# Altium schematic sheet 5V_Project_Microcontrollers_Schematic.SchDoc
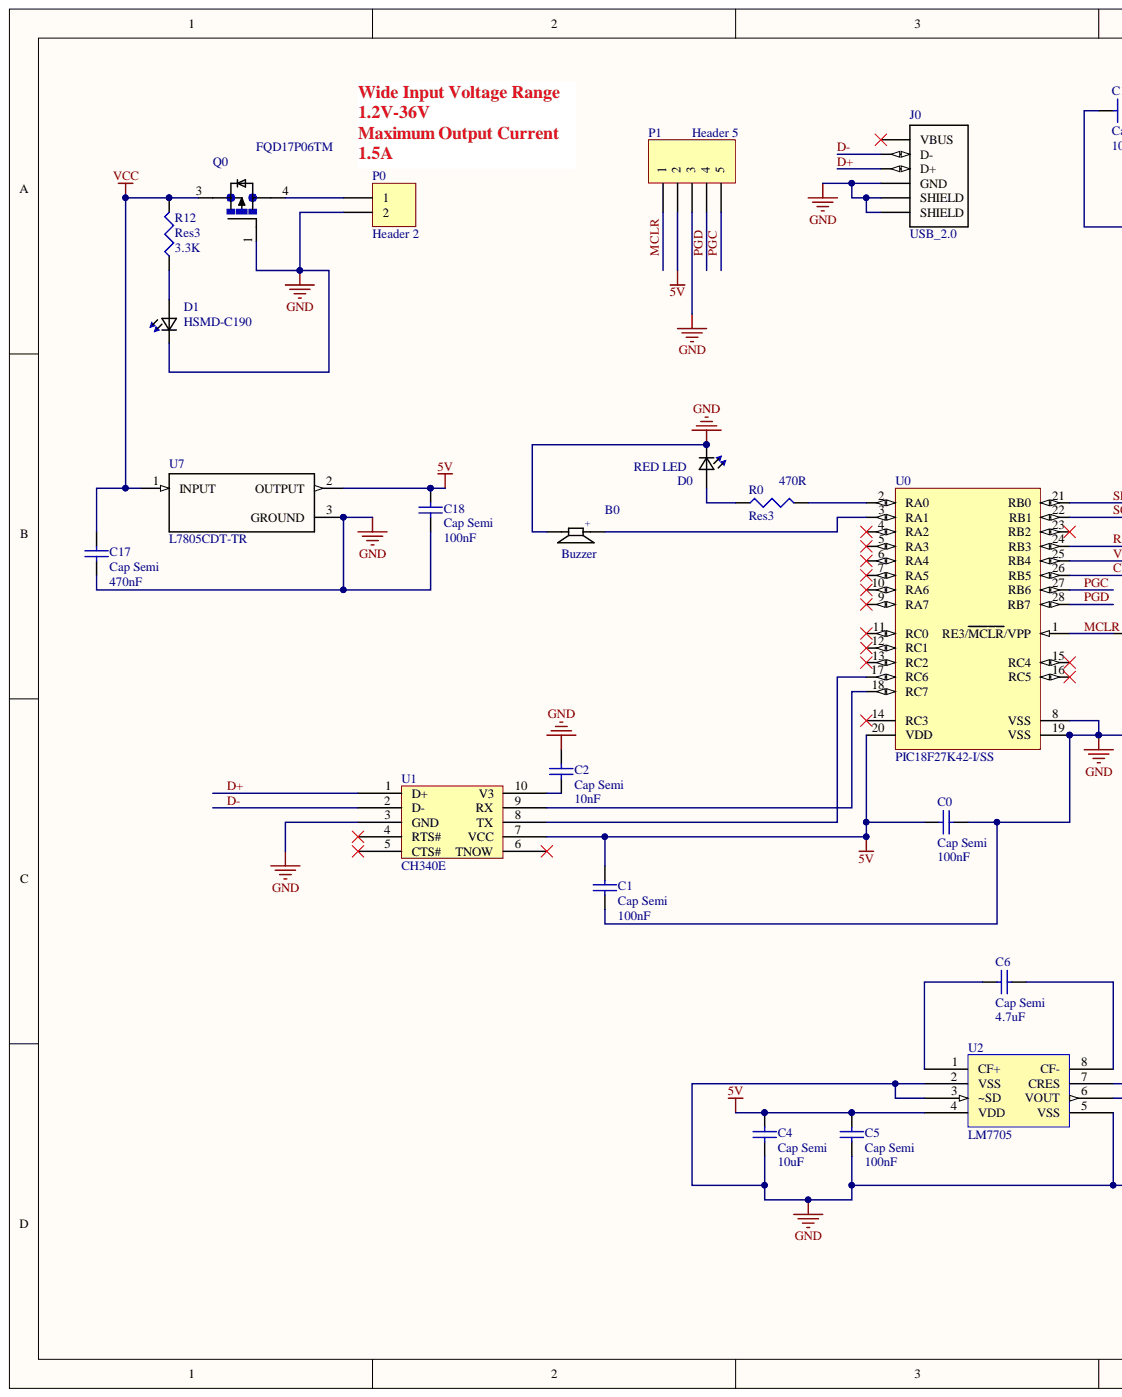
[diagram p0: 5V_Project_Microcontrollers_Schematic.SchDoc, left]
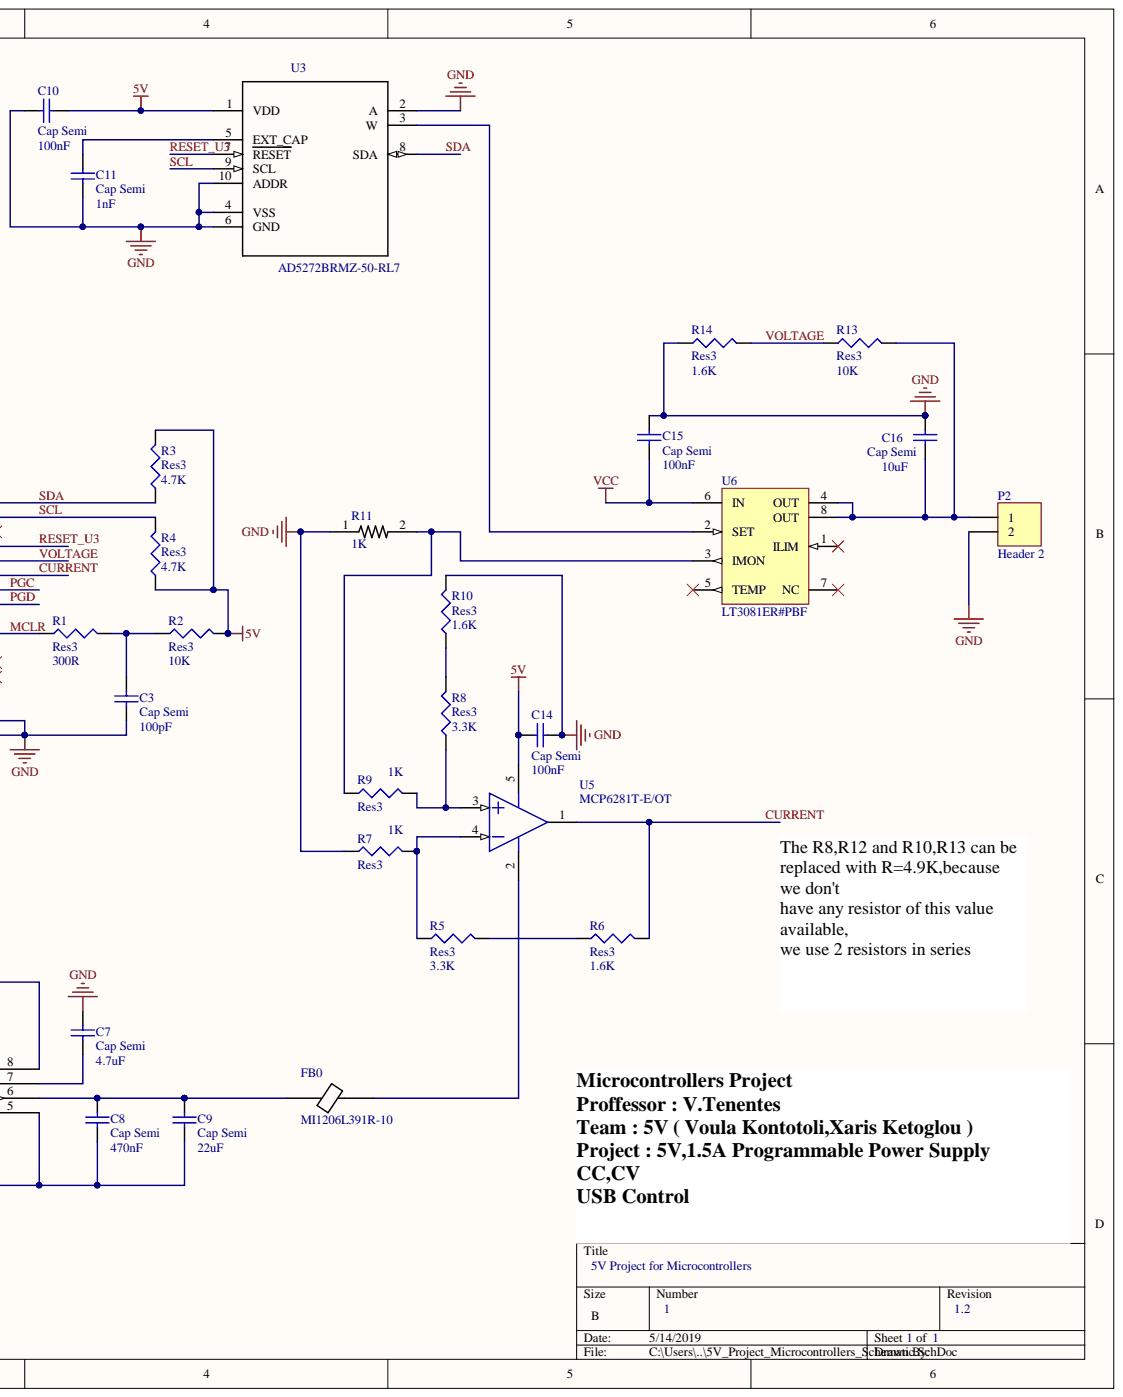
[diagram p1: 5V_Project_Microcontrollers_Schematic.SchDoc, right]

## Parts
B0  Buzzer  [CUI_CEM-1212S_CEM-1212S(Primary)]
C0  Cap Semi  value 100nF  [1608[0603]]
C1  Cap Semi  value 100nF  [1608[0603]]
C2  Cap Semi  value 10nF  [1608[0603]]
C3  Cap Semi  value 100pF  [1608[0603]]
C4  Cap Semi  value 10uF  [1608[0603]]
C5  Cap Semi  value 100nF  [1608[0603]]
C6  Cap Semi  value 4.7uF  [1608[0603]]
C7  Cap Semi  value 4.7uF  [1608[0603]]
C8  Cap Semi  value 470nF  [1608[0603]]
C9  Cap Semi  value 22uF  [1608[0603]]
C10  Cap Semi  value 100nF  [1608[0603]]
C11  Cap Semi  value 1nF  [1608[0603]]
C14  Cap Semi  value 100nF  [1608[0603]]
C15  Cap Semi  value 100nF  [1608[0603]]
C16  Cap Semi  value 10uF  [1608[0603]]
C17  Cap Semi  value 470nF  [1608[0603]]
C18  Cap Semi  value 100nF  [1608[0603]]
D0  RED LED  [0603]
D1  HSMD-C190  [0603]
FB0  MI1206L391R-10  [*BEADC3216X130N]
J0  USB_2.0  [*GCT_USB1046-XX-XXXX-X-B-X_REVB_USB1046-XX-XXXX-X-B-X_REVB(Primary)]
P0  Header 2  [MSTBA 2,5/ 2-G-5,08]
P1  Header 5  [HDR1X5]
P2  Header 2  [HDR1X2]
Q0  FQD17P06TM  [*DPAK229P990X239-4N]
R0  Res3  value 470R  [J1-0603]
R1  Res3  value 300R  [J1-0603]
R2  Res3  value 10K  [J1-0603]
R3  Res3  value 4.7K  [J1-0603]
R4  Res3  value 4.7K  [J1-0603]
R5  Res3  value 3.3K  [J1-0603]
R6  Res3  value 1.6K  [J1-0603]
R7  Res3  value 1K  [J1-0603]
R8  Res3  value 3.3K  [J1-0603]
R9  Res3  value 1K  [J1-0603]
R10  Res3  value 1.6K  [J1-0603]
R11  1K  [*RESC3216X90]
R12  Res3  value 3.3K  [J1-0603]
R13  Res3  value 10K  [J1-0603]
R14  Res3  value 1.6K  [J1-0603]
U0  PIC18F27K42-I/SS  [MCHP-SSOP-SS28_N]
U1  CH340E  [MSOP10]
U2  LM7705  [MUA08A]
U3  AD5272BRMZ-50-RL7  [SOP50P490X110-10N]
U5  MCP6281T-E/OT  [SOT-23-5_MC]
U6  LT3081ER#PBF  [LT-R-7_N]
U7  L7805CDT-TR  [*VREG_L7805CDT-TR]

## Nets
5V: C0.1 C1.1 C4.2 C5.2 C10.2 C14.2 C18.2 P1.2 R2.2 R3.2 R4.1 U0.20(VDD) U1.7(VCC) U2.4(VDD) U3.1(VDD) U5.5 U7.2
GND: B0.M C0.2 C1.2 C2.2 C3.1 C4.1 C5.1 C7.2 C8.1 C9.1 C10.1 C11.1 C14.1 C15.2 C16.2 C17.1 C18.1 D0.1 D1.1 J0.4(GND) J0.P1(SHIELD) J0.P2(SHIELD) P0.2 P1.3 P2.2 Q0.1 R7.1 R10.2 R11.1 R14.1 U0.8(VSS) U0.19(VSS) U1.3(GND) U2.2(VSS) U2.3(~SD) U2.5(VSS) U3.2(A) U3.4(VSS) U3.6(GND) U3.10(ADDR) U7.3
VCC: C15.1 C17.2 Q0.3 R12.2 U6.6(IN) U7.1
CURRENT: R6.2 U0.26(RB5) U5.1
D+: J0.3(D+) U1.1(D+)
D-: J0.2(D-) U1.2(D-)
MCLR: P1.1 R1.1 U0.1(RE3/MCLR/VPP)
PGC: P1.5 U0.27(RB6)
PGD: P1.4 U0.28(RB7)
RESET_U3: U0.24(RB3) U3.7(RESET)
SCL: R4.2 U0.22(RB1) U3.9(SCL)
SDA: R3.1 U0.21(RB0) U3.8(SDA)
VOLTAGE: R13.1 R14.2 U0.25(RB4)
NetB0_P: B0.P U0.3(RA1)
NetC2_1: C2.1 U1.10(V3)
NetC3_2: C3.2 R1.2 R2.1
NetC6_1: C6.1 U2.1(CF+)
NetC6_2: C6.2 U2.8(CF-)
NetC7_1: C7.1 U2.7(CRES)
NetC8_2: C8.2 C9.2 FB0.1 U2.6(VOUT)
NetC11_2: C11.2 U3.5(EXT_CAP)
NetC16_1: C16.1 P2.1 R13.2 U6.4(OUT) U6.8(OUT)
NetD0_2: D0.2 R0.1
NetD1_2: D1.2 R12.1
NetFB0_2: FB0.2 U5.2
NetP0_1: P0.1 Q0.4
NetR0_2: R0.2 U0.2(RA0)
NetR5_1: R5.1 R7.2 U5.4
NetR5_2: R5.2 R6.1
NetR8_1: R8.1 R9.2 U5.3
NetR8_2: R8.2 R10.1
NetR9_1: R9.1 R11.2 U6.3(IMON)
NetU0_17: U0.17(RC6) U1.8(TX)
NetU0_18: U0.18(RC7) U1.9(RX)
NetU3_3: U3.3(W) U6.2(SET)

Not connected: J0 1(VBUS); U0 4(RA2) 5(RA3) 6(RA4) 7(RA5) 9(RA7) 10(RA6) 11(RC0) 12(RC1) 13(RC2) 14(RC3) 15(RC4) 16(RC5) 23(RB2); U1 4(RTS#) 5(CTS#) 6(TNOW); U6 1(ILIM) 5(TEMP) 7(NC)

## Library data of the parts
B0 = CEM-1212S: Component Kind: Standard; Library Name: buzzer.IntLib
C0-C9 C11 C15-C18 = Cap Semi: Capacitor (Semiconductor SIM Model); Component Kind: Standard; LatestRevisionDate: 29-May-2009; LatestRevisionNote: IPC-7351 Footprint Added.; Library Name: Miscellaneous Devices.IntLib; PackageDescription: Chip Capacitor; PackageReference: C1206; Published: 8-Jun-2000; Signal Integrity: Cap Semi; Simulation: CAP; Publisher: Altium Limited
C10 C14 = Cap Semi: Capacitor (Semiconductor SIM Model); Value: 100nF; Component Kind: Standard; LatestRevisionDate: 29-May-2009; LatestRevisionNote: IPC-7351 Footprint Added.; Library Name: Miscellaneous Devices.IntLib; PackageDescription: Chip Capacitor; PackageReference: C1206; Published: 8-Jun-2000; Signal Integrity: Cap Semi; Simulation: CAP; Publisher: Altium Limited
D0 D1 = HSMD-C190: LED Uni-Color Orange 605nm 2-Pin Chip LED T/R; MF: Broadcom Limited; Availability: Unavailable; Component Kind: Standard; Library Name: LED_SMD.IntLib; MP: HSMD-C190; Package: 0603 Broadcom; Price: None; SnapEDA_Link: https://www.snapeda.com/parts/HSMD-C190/Broadcom%20Limited/view-part/?ref=snap
FB0 = MI1206L391R-10: EMI Ferrite Chip Bead, 1206, 2000MA, 390 Ohms @100MHz, DCR Max 0.080Ohm; MF: Laird-Signal Integrity; Availability: Unavailable; Component Kind: Standard; Library Name: Ferrite_Bead.SchLib; MP: MI1206L391R-10; Package: 1206 Laird; Price: None; SnapEDA_Link: https://www.snapeda.com/parts/MI1206L391R-10/Laird-Signal%20Integrity%20Products/view-part/?ref=snap
J0 = USB1046-XX-XXXX-X-B-X_REVB: USB A Skt, Top Mount, SMT, R/A, GF, Black, With 1.9mm shell stake, T&R; MF: Global Connector Technology; MP: USB1046-GF-0190-L-B-A; Availability: Unavailable; Component Kind: Standard; Library Name: USB1046-XX-XXXX-X-B-X_REVB.IntLib; Package: None; Price: None; SnapEDA_Link: https://www.snapeda.com/parts/USB1046-GF-0190-L-B-A/Global%20Connector%20Technology/view-part/?ref=snap
P0 P2 = Header 2: Header, 2-Pin; Component Kind: Standard; LatestRevisionDate: 17-Jul-2002; LatestRevisionNote: Re-released for DXP Platform.; Library Name: Miscellaneous Connectors.IntLib; Signal Integrity: Connector; Publisher: Altium Limited
P1 = Header 5: Header, 5-Pin; Component Kind: Standard; LatestRevisionDate: 17-Jul-2002; LatestRevisionNote: Re-released for DXP Platform.; Library Name: Miscellaneous Connectors.IntLib; Signal Integrity: Connector; Publisher: Altium Limited
Q0 = FQD17P06TM: Transistor: P-MOSFET; unipolar; 60V; 7.6A; 44W; DPAK; QFETÂ®; MF: ON Semiconductor; Availability: Unavailable; Component Kind: Standard; Library Name: FQD17P06TM.SchLib; MP: FQD17P06TM; Package: TO-252-3 ON Semiconductor; Price: None; SnapEDA_Link: https://www.snapeda.com/parts/FQD17P06TM/ON%20Semiconductor/view-part/?ref=snap
R0-R10 R12-R14 = Res3: Resistor; Component Kind: Standard; LatestRevisionDate: 29-May-2009; LatestRevisionNote: IPC-7351 Footprint Added.; Library Name: Miscellaneous Devices.IntLib; PackageDescription: Chip Resistor; PackageReference: J1-0603; Published: 8-Jun-2000; Signal Integrity: Res; Simulation: RESISTOR; Publisher: Altium Limited
R11 = WSLP12061L000FEA: SMD Current Sense Resistors, AEC-Q200 WSLP Series, 0.001 ohm, 1 W, 1%, 1206 [3216 Metric]; MF: Vishay Dale; Availability: Unavailable; Component Kind: Standard; Library Name: 1206_FOOTPRINT.SchLib; MP: WSLP12061L000FEA; Package: 1206 Vishay; Price: None; SnapEDA_Link: https://www.snapeda.com/parts/WSLP12061L000FEA/Vishay%20Dale/view-part/?ref=snap
U0 = PIC18F25K50-I/SS: Low-Power, High-Performance Microcontroller with XLP Technology, 48 MHz, 24 I/O, -40 to 85 degC, 28-pin SSOP (SS28), Tube; Manufacturer: Microchip; # of A/D Channels: 14; Digital Communication: 1 -A/E/USART, 1 -MSSP(SPI/I2C); EEPROM Data Memory (bytes): 256; I/O Pins: 24; Internal Oscillator: 16 MHz, 31 kHz; Max. CPU Speed MHz: 48; Memory Type: Flash; Operation Voltage Range: 1.8V - 5.5V; Program Memory KBytes: 32; Program Memory KWords: 16; RAM: 2048; Timers: 2 - 8-bit, 2 - 16-bit; Component Kind: Standard; ComponentLink1Description: Datasheet; ComponentLink1URL: http://ww1.microchip.com/downloads/en/DeviceDoc/30684A.pdf; ComponentLink2Description: Package Specification; ComponentLink2URL: http://www.microchip.com/stellent/groups/techpub_sg/documents/packagingspec/en012702.pdf; ComponentLink3Description: URL Link1; ComponentLink3URL: Product URL:http://www.microchip.com/datasheet/PIC18F25K50; DatasheetVersion: Rev. A; Library Name: Microchip PIC18.IntLib; Mounting Technology: Surface Mount; PackageDescription: 28-Lead Plastic Shrink Small Outline, 10.2 x 5.3 mm Body, 0.65 mm Pitch; PackageReference: SSOP-SS28; PackageVersion: C04-073B, 12/2012; Packing: TUBE; PartNumber: PIC18F25K50-I/SS; RoHS: TRUE; Supplier 1: Digi-Key; Supplier 2: Farnell; Supplier 3: Newark; Supplier 4: Mouser; Supplier Part Number 1: PIC18F25K50-I/SS-ND; Supplier Part Number 2: 2305833; Supplier Part Number 3: 06W2974; Supplier Part Number 4: 579-PIC18F25K50-I/SS
U1 = CH340E: TTL IC; Component Kind: Standard; Library Name: Custom Library.SchLib
U2 = LM7705MMX/NOPB: Imported; 3D_Library_Name: National_12-15-2008b; C: Copyright (C) 2015 Accelerated Designs. All rights reserved; Vendor: Texas Instruments; Component Kind: Standard; Manufacturer_Part_Number: LM7705MMX/NOPB; RefDes: RefDes; Type: Type; Value: Value; Copyright: Copyright (C) 2015 Accelerated Designs. All rights reserved; Library Name: tcb_mb.SchLib
U3 = AD5272BRMZ-50-RL7: Digital Potentiometer 1024POS 50KOhm Single 10-Pin MSOP T/R; MF: Analog Devices; Availability: Unavailable; Component Kind: Standard; Library Name: AD5272BRMZ-50-RL7.IntLib; MP: AD5272BRMZ-50-RL7; Package: MSOP-10 Analog Devices; Price: None; SnapEDA_Link: https://www.snapeda.com/parts/AD5272BRMZ-50-RL7/Analog%20Devices%20Inc./view-part/?ref=snap
U5 = MCP6281T-E/OT: Imported; C: Copyright (C) 2015 Accelerated Designs. All rights reserved; Vendor: Microchip; Component Kind: Standard; Manufacturer_Part_Number: MCP6281T-E/OT; RefDes: RefDes; Type: Type; Value: Value; Copyright: Copyright (C) 2015 Accelerated Designs. All rights reserved; Library Name: tcb_mb.SchLib
U6 = LT3081ER#PBF: 1.5 A Single Resistor Rugged Linear Regulator with Monitor, 1.2 to 36 V Vin, Adjustable Vout, 7-pin DPAK (R-7), -40 to 125 degC, Pb-Free; Manufacturer: Linear Technology; Discrete Pass Element: no; Dropout Voltage(V): 1.21; Iout(mA): 1500; Isupply(mA): 1.1; Noise(uV): 27; Number of Outputs: 1; Output Voltage: Adj; PSRR(dB): 90; Vin Max(V): 36; Vin Min(V): 1.2; Vout Max(V): 34.5; Vout Min(V): 0; Component Kind: Standard; ComponentLink1Description: Manufacturer URL; ComponentLink1URL: http://www.linear.com/; ComponentLink2Description: Datasheet; ComponentLink2URL: http://cds.linear.com/docs/en/datasheet/3081f.pdf; ComponentLink3Description: Package Specification; ComponentLink3URL: http://cds.linear.com/docs/en/packaging/05081462_F_R.pdf; Library Name: Linear Technology Power Management LDO Linear Regulators.IntLib; Mounting Technology: Surface Mount; PackageDescription: 7-Pin Plastic DD Pak, Body 10.2235 x 8.89 mm, Pitch 1.27 mm; PackageReference: R-7; PackageVersion: Rev. F, 05/2012; PartNumber: LT3081ER#PBF; RoHS: TRUE; Supplier 1: Digi-Key; Supplier 2: Newark; Supplier Part Number 1: LT3081ER#PBF-ND; Supplier Part Number 2: 94W8442
U7 = L7805CDT-TR: L7805 Series 4.8 V 1.5 A Three Terminal Positive Voltage Regulator - TO-252-3; MF: STMicroelectronics; Availability: Unavailable; Component Kind: Standard; Library Name: L7805CDT-TR.SchLib; MP: L7805CDT-TR; Package: TO-252 STMicroelectronics; Price: None; SnapEDA_Link: https://www.snapeda.com/parts/L7805CDT-TR/STMicroelectronics/view-part/?ref=snap
